# Altium schematic sheet Sheet2.SchDoc
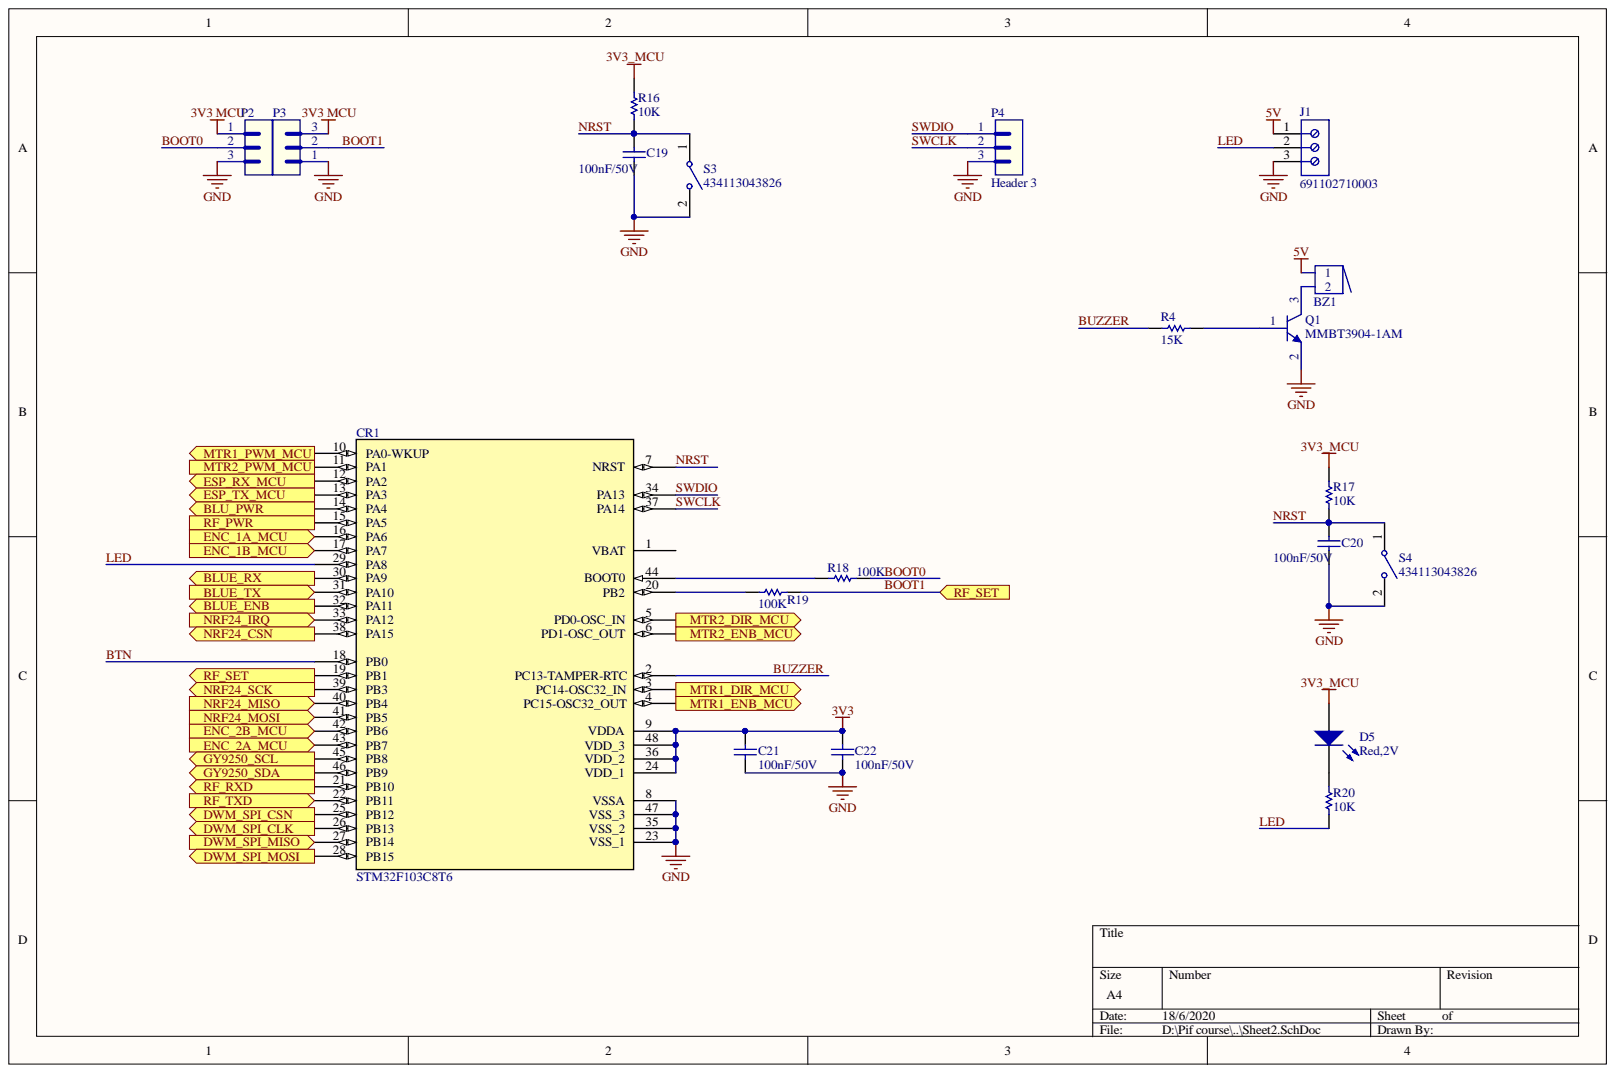
[diagram p0: Sheet2.SchDoc]

## Parts
BZ1  Buzzer  [BUZZER]  (value not drawn)
C19  value 100nF/50V  [CAP-SM-0805]  (hidden comment: Cap-1nF-50V-0805)
C20  value 100nF/50V  [CAP-SM-0805]  (hidden comment: Cap-1nF-50V-0805)
C21  value 100nF/50V  [CAP-SM-0805]  (hidden comment: Cap-1nF-50V-0805)
C22  value 100nF/50V  [CAP-SM-0805]  (hidden comment: Cap-1nF-50V-0805)
CR1  STM32F103C8T6  [STM32F103C8T6]
D5  value Red,2V  [LED-3mm]  (hidden comment: LED-RED-TH-3mm)
J1  691102710003  [691102710003]
P2  (no value)  [jp3]
P3  (no value)  [jp3]
P4  Header 3  [jp3]
Q1  MMBT3904-1AM  [SOT23_NEX]
R4  value 15K  [RES-SM-0805]  (hidden comment: Res-12K-0805)
R16  value 10K  [RES-SM-0805]  (hidden comment: Res-0-0805)
R17  value 10K  [RES-SM-0805]  (hidden comment: Res-0-0805)
R18  value 100K  [RES-SM-0805]  (hidden comment: Res-1K-0805)
R19  Res-1K-0805  [RES-SM-0805]  (value not drawn)
R20  value 10K  [RES-SM-0805]  (hidden comment: Res-0-0805)
S3  434113043826  [434113043826]
S4  434113043826  [434113043826]

## Ports
BLU_PWR, BLUE_ENB, BLUE_RX, BLUE_TX, DWM_SPI_CLK, DWM_SPI_CSN, DWM_SPI_MISO, DWM_SPI_MOSI, ENC_1A_MCU, ENC_1B_MCU, ENC_2A_MCU, ENC_2B_MCU, ESP_RX_MCU, ESP_TX_MCU, GY9250_SCL, GY9250_SDA, MTR1_DIR_MCU, MTR1_ENB_MCU, MTR1_PWM_MCU, MTR2_DIR_MCU, MTR2_ENB_MCU, MTR2_PWM_MCU, NRF24_CSN, NRF24_IRQ, NRF24_MISO, NRF24_MOSI, NRF24_SCK, RF_PWR, RF_RXD, RF_SET, RF_TXD

## Nets
3V3: C21.2 C22.2 CR1.9(VDDA) CR1.24(VDD_1) CR1.36(VDD_2) CR1.48(VDD_3)
3V3 MCU: P2.1 P3.3
3V3_MCU: D5.1 R16.2 R17.2
5V: BZ1.1 J1.1
GND: C19.1 C20.1 C21.1 C22.1 CR1.8(VSSA) CR1.23(VSS_1) CR1.35(VSS_2) CR1.47(VSS_3) J1.3 P2.3 P3.1 P4.3 Q1.2 S3.2 S4.2
BLU_PWR: CR1.14(PA4) ; port BLU_PWR
BLUE_ENB: CR1.32(PA11) ; port BLUE_ENB
BLUE_RX: CR1.30(PA9) ; port BLUE_RX
BLUE_TX: CR1.31(PA10) ; port BLUE_TX
BOOT0: P2.2 R18.2
BOOT1: CR1.19(PB1) P3.2 R19.2 ; port RF_SET
BTN: CR1.18(PB0)
BUZZER: CR1.2(PC13-TAMPER-RTC) R4.1
DWM_SPI_CLK: CR1.26(PB13) ; port DWM_SPI_CLK
DWM_SPI_CSN: CR1.25(PB12) ; port DWM_SPI_CSN
DWM_SPI_MISO: CR1.27(PB14) ; port DWM_SPI_MISO
DWM_SPI_MOSI: CR1.28(PB15) ; port DWM_SPI_MOSI
ENC_1A_MCU: CR1.16(PA6) ; port ENC_1A_MCU
ENC_1B_MCU: CR1.17(PA7) ; port ENC_1B_MCU
ENC_2A_MCU: CR1.43(PB7) ; port ENC_2A_MCU
ENC_2B_MCU: CR1.42(PB6) ; port ENC_2B_MCU
ESP_RX_MCU: CR1.12(PA2) ; port ESP_RX_MCU
ESP_TX_MCU: CR1.13(PA3) ; port ESP_TX_MCU
GY9250_SCL: CR1.45(PB8) ; port GY9250_SCL
GY9250_SDA: CR1.46(PB9) ; port GY9250_SDA
LED: CR1.29(PA8) J1.2 R20.1
MTR1_DIR_MCU: CR1.3(PC14-OSC32_IN) ; port MTR1_DIR_MCU
MTR1_ENB_MCU: CR1.4(PC15-OSC32_OUT) ; port MTR1_ENB_MCU
MTR1_PWM_MCU: CR1.10(PA0-WKUP) ; port MTR1_PWM_MCU
MTR2_DIR_MCU: CR1.5(PD0-OSC_IN) ; port MTR2_DIR_MCU
MTR2_ENB_MCU: CR1.6(PD1-OSC_OUT) ; port MTR2_ENB_MCU
MTR2_PWM_MCU: CR1.11(PA1) ; port MTR2_PWM_MCU
NRF24_CSN: CR1.38(PA15) ; port NRF24_CSN
NRF24_IRQ: CR1.33(PA12) ; port NRF24_IRQ
NRF24_MISO: CR1.40(PB4) ; port NRF24_MISO
NRF24_MOSI: CR1.41(PB5) ; port NRF24_MOSI
NRF24_SCK: CR1.39(PB3) ; port NRF24_SCK
NRST: C19.2 C20.2 CR1.7(NRST) R16.1 R17.1 S3.1 S4.1
RF_PWR: CR1.15(PA5) ; port RF_PWR
RF_RXD: CR1.21(PB10) ; port RF_RXD
RF_TXD: CR1.22(PB11) ; port RF_TXD
SWCLK: CR1.37(PA14) P4.2
SWDIO: CR1.34(PA13) P4.1
NetBZ1_2: BZ1.2 Q1.3
NetCR1_20: CR1.20(PB2) R19.1
NetCR1_44: CR1.44(BOOT0) R18.1
NetD5_2: D5.2 R20.2
NetQ1_1: Q1.1 R4.2

Not connected: CR1 1(VBAT)

## Library data of the parts
C19-C22 = Cap-1nF-50V-0805: CAP CER 1000PF 100V X7R 0805; Manufacturer: Johanson Dielectrics Inc.; Manufacturer Part Number: 101X15W102MV4E; Component Kind: Standard; Library Name: Capacitor.SchLib; Local Supplier: thegioiic; Local Supplier Link: https://thegioiic.com/products/tu-1nf-50v-0805; Supplier 1: Digikey; Supplier 1 Link: https://www.digikey.com/product-detail/en/johanson-dielectrics-inc/101X15W102MV4E/709-1335-1-ND/2327235; Supplier 1 Part Number: 709-1335-1-ND
CR1 = STM32F103C8T6: Built_By: EMA_UL_Team; SourceLibrary: StMicro_2011-06-07; Type: DEV; Vendor: STMicroelectronics; Component Kind: Standard; Library Name: Integrated_Library.IntLib; Manufacturer_Part_Number: STM32F103C8T6; RefDes: RefDes; Copyright: Copyright (C) 2018 Accelerated Designs. All rights reserved
D5 = LED-RED-TH-3mm: LED RED CLEAR T-1 T/H; Manufacturer: Kingbright; Manufacturer Part Number: WP710A10SEC/J3; Component Kind: Standard; Library Name: LED.SchLib; Local Supplier: thegioiic; Local Supplier Link: https://thegioiic.com/products/led-do-3mm-chan-dai; Supplier 1: Digikey; Supplier 1 Link: https://www.digikey.com/product-detail/en/kingbright/WP710A10SEC-J3/754-1589-ND/2769815; Supplier 1 Part Number: 754-1589-ND
J1 = 691102710003: WR-TBL Serie 102 Horizontal Entry Modular, Pressure Clamp, pitch 5mm, 3p; Compliance: Lead free / RoHS; Modularity: Yes; PCB/Cable/Panel: PCB; Pin Number: 3; Rated Current [UL]: 15A; Working Voltage [UL]: 250VAC; Application: Pressure Clamp; Component Kind: Standard; ComponentLink1Description: Manufacturer; ComponentLink1URL: http://www.we-online.de; ComponentLink2Description: Datasheet; ComponentLink2URL: https://katalog.we-online.de/em/datasheet/691102710003.pdf; Library Name: 691101710002_Altium_WR-TBL_rev19a.IntLib; Mounting Technology: THT; Operating Temperature: -40 to +105degC; Packaging: Box; Part Number: 691102710003; Pitch: 5mm; Published Date: October 2019; Type: Horizontal; Publisher: Wurth Elektronik
P2-P4 = Header 3: Header, 3-Pin; Component Kind: Standard; LatestRevisionDate: 17-Jul-2002; LatestRevisionNote: Re-released for DXP Platform.; Library Name: Integrated_Library_Test.IntLib; Publisher: Altium Limited
R4 = Res-12K-0805: RES 12 KOHM JUMPER 0805; Manufacturer: Vishay Thin Film; Manufacturer Part Number: PAT0805E3121BST1; Component Kind: Standard; Library Name: Resistor.SchLib; Local Supplier: icdayroi; Local Supplier Link: https://icdayroi.com/dien-tro-12k-0805-1-goi-50-con; Supplier 1: Digikey; Supplier 1 Link: https://www.digikey.com/product-detail/en/vishay-thin-film/PAT0805E3121BST1/PAT0805E3121BST1-ND/2281536; Supplier 1 Part Number: PAT0805E3121BST1-ND
R16 R17 R20 = Res-0-0805: RES 0 OHM JUMPER 0805; Manufacturer: Vishay Dale; Manufacturer Part Number: WSL080500000ZEA9; Component Kind: Standard; Library Name: Resistor.SchLib; Local Supplier: thegioiic; Local Supplier Link: https://thegioiic.com/products/dien-tro-0-ohm-0805-5; Supplier 1: Digikey; Supplier 1 Link: https://www.digikey.com/product-detail/en/vishay-dale/WSL080500000ZEA9/541-3928-1-ND/7423223; Supplier 1 Part Number: 541-3928-1-ND
R18 = Res-1K-0805: RES 1K OHM 1% 1/4W 0805; Manufacturer: Stackpole Electronics Inc; Manufacturer Part Number: RNCP0805FTD1K00; Component Kind: Standard; Library Name: Resistor.SchLib; Local Supplier: thegioiic; Local Supplier Link: https://thegioiic.com/products/dien-tro-1k-ohm-0805-5; Supplier 1: Digikey; Supplier 1 Link: https://www.digikey.com/product-detail/en/stackpole-electronics-inc/RNCP0805FTD1K00/RNCP0805FTD1K00CT-ND/2240568; Supplier 1 Part Number: RNCP0805FTD1K00CT-ND
R19 = Res-1K-0805: RES 1K OHM 1% 1/4W 0805; Manufacturer: Stackpole Electronics Inc; Manufacturer Part Number: RNCP0805FTD1K00; Value: 100K; Component Kind: Standard; Library Name: Resistor.SchLib; Local Supplier: thegioiic; Local Supplier Link: https://thegioiic.com/products/dien-tro-1k-ohm-0805-5; Supplier 1: Digikey; Supplier 1 Link: https://www.digikey.com/product-detail/en/stackpole-electronics-inc/RNCP0805FTD1K00/RNCP0805FTD1K00CT-ND/2240568; Supplier 1 Part Number: RNCP0805FTD1K00CT-ND
S3 S4 = 434113043826: WS-TASV J-Bend SMT Tact Switch 6x3.5mm, height 4.3mm, 260gf; Rated Current: 50mA; Rated Voltage: 12VDC; Washable: No; Component Kind: Standard; ComponentLink1Description: Manufacturer; ComponentLink1URL: http://www.we-online.de; ComponentLink2Description: Datasheet; ComponentLink2URL: https://katalog.we-online.com/em/datasheet/434113043826.pdf; Contact Material: Stainless Steel; Contact Plating: Silver; Height: 4.3mm; Library Name: 434113043826_Altium_WS-TASV_rev20a.IntLib; Mounting Technology: SMT; Operating Temperature: -40 to +85degC; Operation Force: 260gf; Packaging: Tape and Reel; Part Number: 434113043826; Published Date: September 2019; Terminal Material: Copper Alloy; Terminal Plating: Silver; Publisher: Wurth Elektronik
BZ1 = Buzzer: Component Kind: Standard; Library Name: PIF_C16_lib.IntLib
Q1 = MMBT3904-1AM: Component Kind: Standard; Library Name: BJT.SchLib
